# Altium schematic sheet Analogsignal.SchDoc
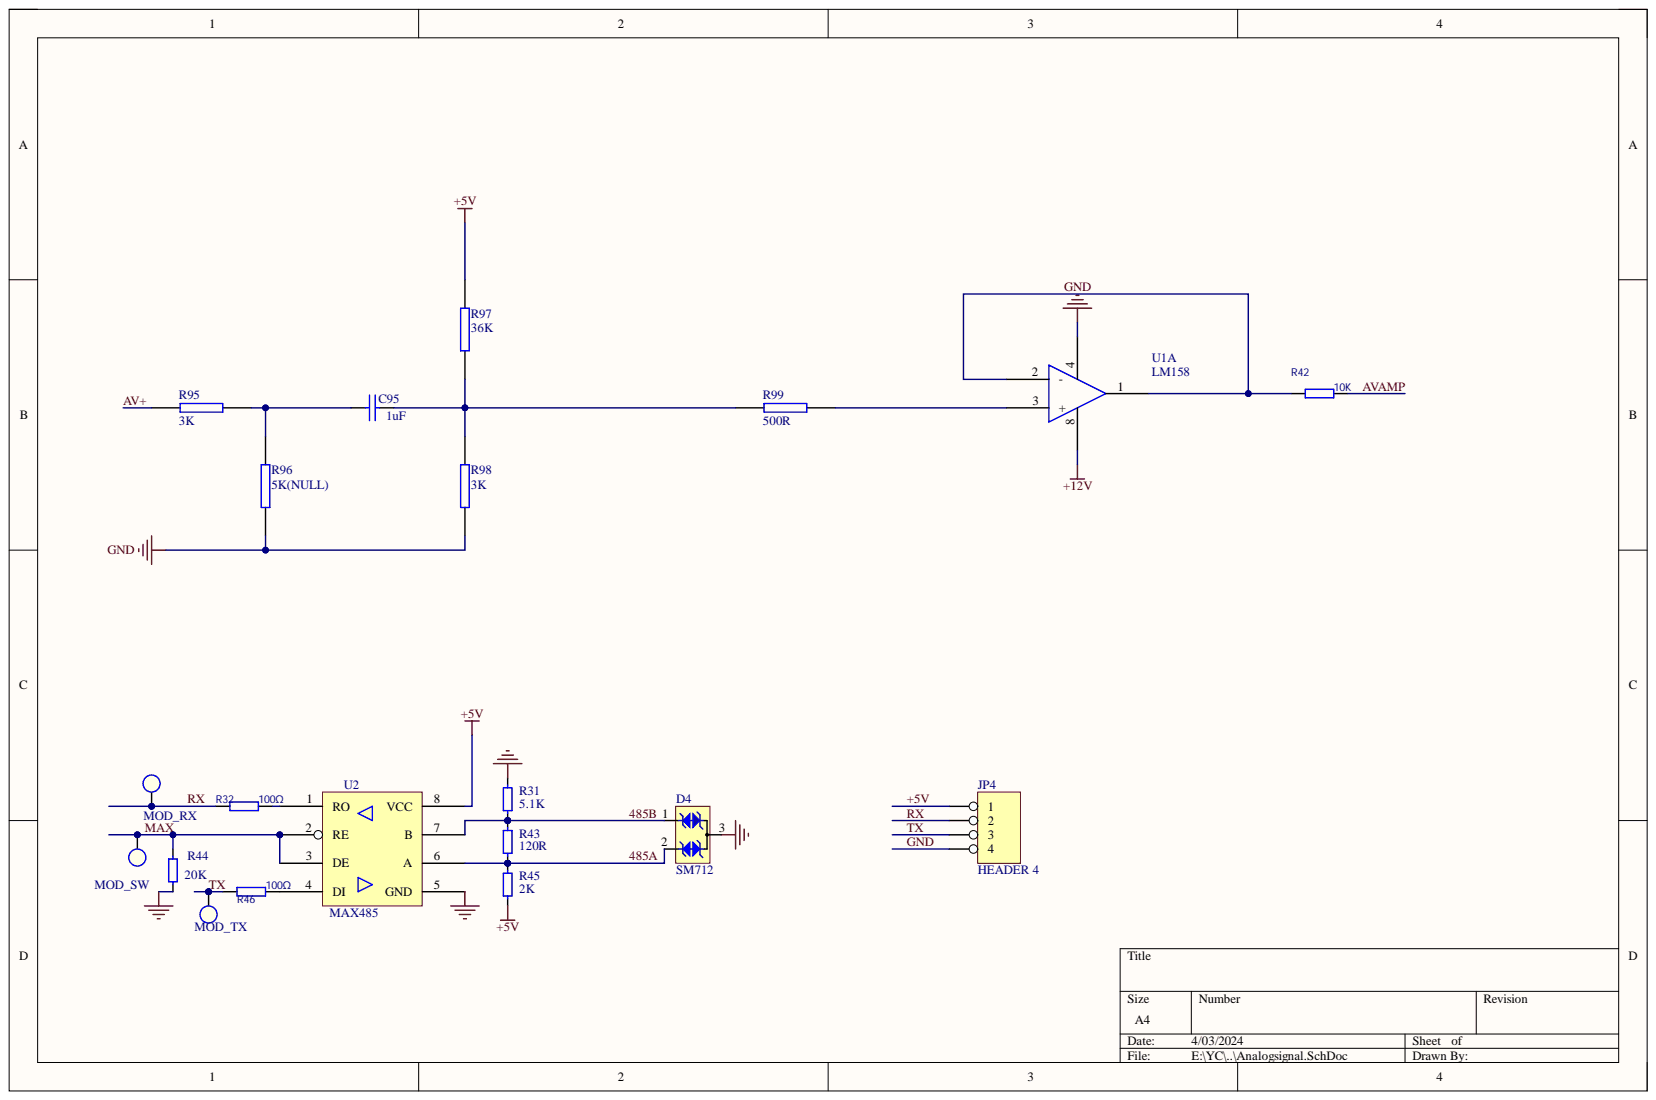
[diagram p0: Analogsignal.SchDoc]

## Parts
C95  1uF  [0805C]
D4  SM712  [SOT23]
JP4  HEADER 4  [SIP4]
MOD_RX  TERM  [TERM]  (value not drawn)
MOD_SW  TERM  [TERM]  (value not drawn)
MOD_TX  TERM  [TERM]  (value not drawn)
R31  5.1K  [0805]
R32  100Ω  [0805]
R42  10K  [0805]
R43  120R  [0805]
R44  20K  [0805]
R45  2K  [0805]
R46  100Ω  [0805]
R95  3K  [0805]
R96  5K(NULL)  [0805]
R97  36K  [0805]
R98  3K  [0805]
R99  500R  [0805]
U1  LM158  [SOIC-8]  (part A)
U2  MAX485  [SOIC8]

## Nets
+12V: U1.8
485A: D4.2 R43.1 R45.2 U2.6(A)
485B: D4.1 R31.1 R43.2 U2.7(B)
+5V: JP4.1 R45.1 R97.1 U2.8(VCC)
AV+: R95.2
AVAMP: R42.2
GND: D4.3 JP4.4 R31.2 R44.1 R96.2 R98.2 U1.4 U2.5(GND)
MAX: MOD_SW.1 R44.2 U2.2(RE) U2.3(DE)
RX: JP4.2 MOD_RX.1 R32.2
TX: JP4.3 MOD_TX.1 R46.2
NetC95_1: C95.1 R95.1 R96.1
NetC95_2: C95.2 R97.2 R98.1 R99.1
NetR32_1: R32.1 U2.1(RO)
NetR42_1: R42.1 U1.1 U1.2(-)
NetR46_1: R46.1 U2.4(DI)
NetR99_2: R99.2 U1.3(+)

## Library data of the parts
C95 = CAP: Capacitor; Value: 0.1uF; Component Kind: Standard; Library Name: 3-4.SCHLIB
JP4 = 4 HEADER: 4 Pin Header; Component Kind: Standard
R31 R44 = RES8: Resistor; Component Kind: Standard
  R31: Value: 5.1K
  R44: Value: 20K
R32 R42 R46 = RES: Component Kind: Standard; Library Name: sixarms--BGA.SCHLIB
R43 = RES8: Resistor; Value: 390R; Component Kind: Standard
R45 = RES8: Resistor; Value: 5.1K; Component Kind: Standard
R95-R99 = R: Resistor; Value: 1M; Component Kind: Standard; Library Name: 杂元件库.SCHLIB
U2 = MAX487: Component Kind: Standard
D4 = SM712: Component Kind: Standard; Library Name: Previous Backup of Schlib1.Lib
MOD_RX MOD_SW MOD_TX = TERM: Component Kind: Standard; Library Name: TR_SCHLIB.SchLib
U1 = LM158: Component Kind: Standard; Library Name: ERSC-HT-MCtrl_V2.0.SCHLIB
